# Altium schematic sheet PVCtrl.SchDoc(PVCtrl)
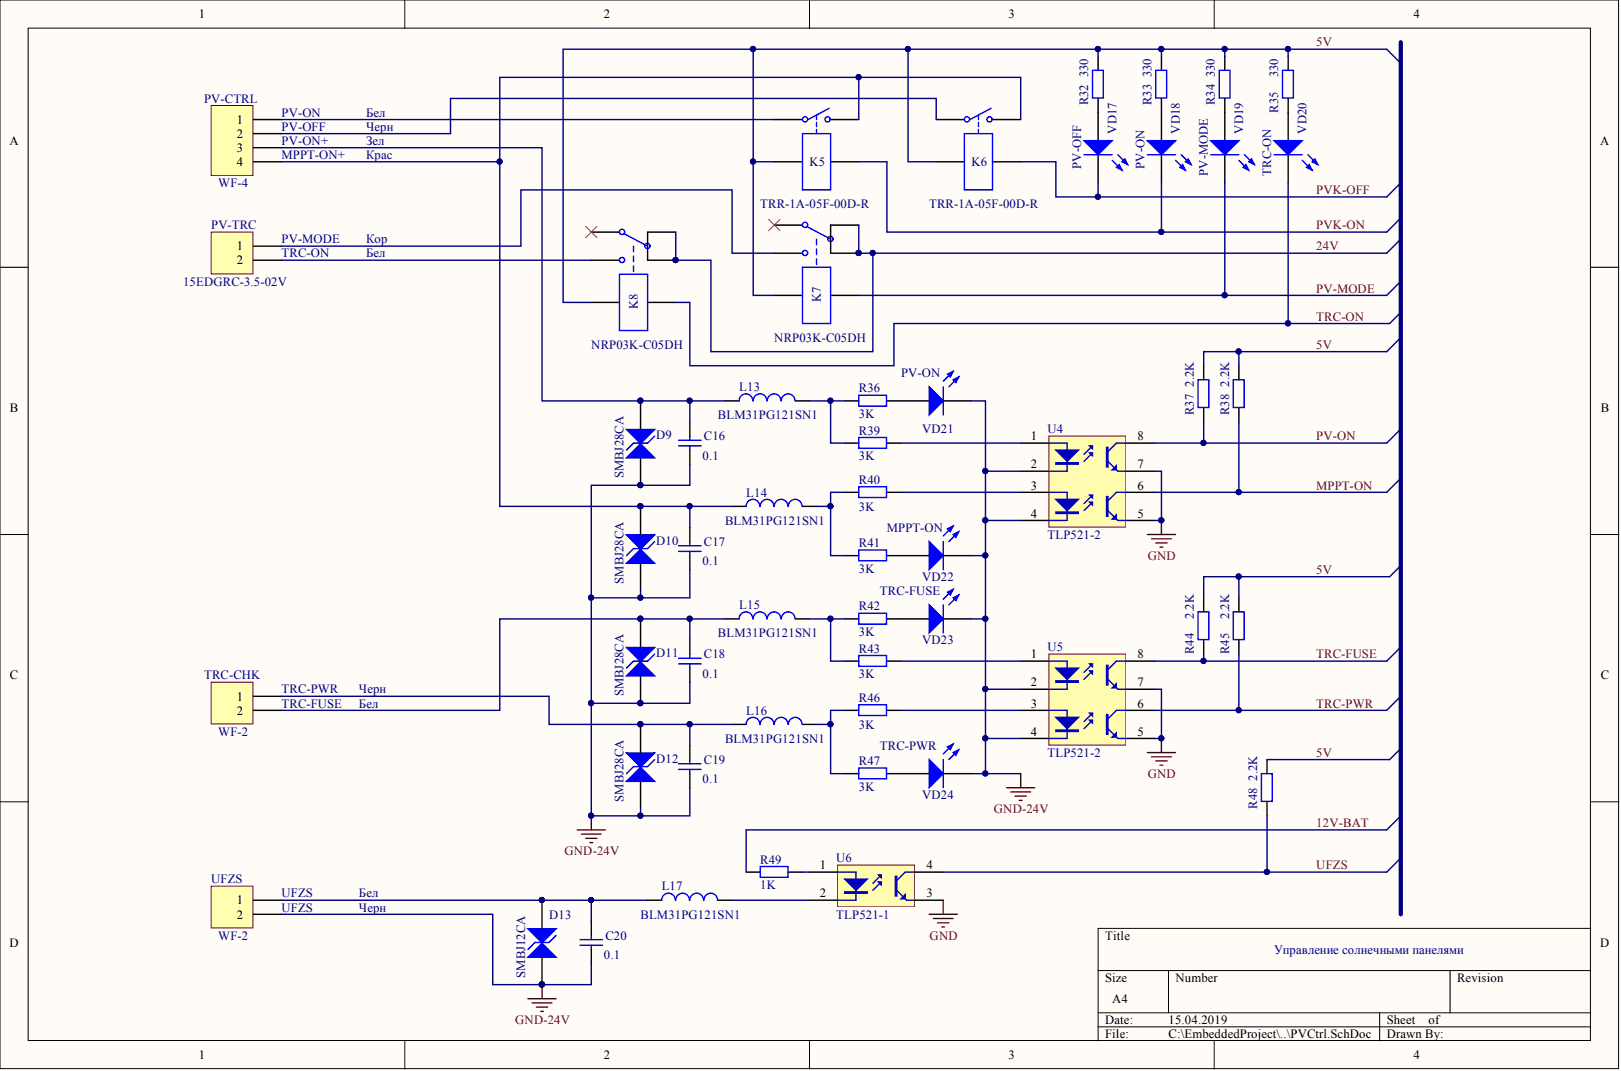
[diagram p0: PVCtrl.SchDoc]

## Parts
C16  value 0.1  [C1206]  (hidden comment: Cap)
C17  value 0.1  [C1206]  (hidden comment: Cap)
C18  value 0.1  [C1206]  (hidden comment: Cap)
C19  value 0.1  [C1206]  (hidden comment: Cap)
C20  value 0.1  [C1206]  (hidden comment: Cap)
D9  SMBJ28CA  [DO-214AA]
D10  SMBJ28CA  [DO-214AA]
D11  SMBJ28CA  [DO-214AA]
D12  SMBJ28CA  [DO-214AA]
D13  SMBJ12CA  [DO-214AA]
K5  value TRR-1A-05F-00D-R  [TRR-1A-05F-00D-R]  (hidden comment: Single-Pole Single-Throw Relay)
K6  value TRR-1A-05F-00D-R  [TRR-1A-05F-00D-R]  (hidden comment: Single-Pole Single-Throw Relay)
K7  value NRP03K-C05DH  [NRP03K-C05DH]  (hidden comment: Single-Pole Dual-Throw Relay)
K8  value NRP03K-C05DH  [NRP03K-C05DH]  (hidden comment: Single-Pole Dual-Throw Relay)
L13  value BLM31PG121SN1  [L1206]  (hidden comment: Ferrite Chip Beads)
L14  value BLM31PG121SN1  [L1206]  (hidden comment: Ferrite Chip Beads)
L15  value BLM31PG121SN1  [L1206]  (hidden comment: Ferrite Chip Beads)
L16  value BLM31PG121SN1  [L1206]  (hidden comment: Ferrite Chip Beads)
L17  value BLM31PG121SN1  [L1206]  (hidden comment: Ferrite Chip Beads)
PV-CTRL  value WF-4  [WF-4]  (hidden comment: Header, 4-Pin)
PV-TRC  value 15EDGRC-3.5-02V  [15EDGRC-3.5-02V]  (hidden comment: Header 2)
R32  value 330  [1206]  (hidden comment: Res2)
R33  value 330  [1206]  (hidden comment: Res2)
R34  value 330  [1206]  (hidden comment: Res2)
R35  value 330  [1206]  (hidden comment: Res2)
R36  value 3K  [1206]  (hidden comment: Res2)
R37  value 2.2K  [1206]  (hidden comment: Res2)
R38  value 2.2K  [1206]  (hidden comment: Res2)
R39  value 3K  [1206]  (hidden comment: Res2)
R40  value 3K  [1206]  (hidden comment: Res2)
R41  value 3K  [1206]  (hidden comment: Res2)
R42  value 3K  [1206]  (hidden comment: Res2)
R43  value 3K  [1206]  (hidden comment: Res2)
R44  value 2.2K  [1206]  (hidden comment: Res2)
R45  value 2.2K  [1206]  (hidden comment: Res2)
R46  value 3K  [1206]  (hidden comment: Res2)
R47  value 3K  [1206]  (hidden comment: Res2)
R48  value 2.2K  [1206]  (hidden comment: Res2)
R49  value 1K  [1206]  (hidden comment: Res2)
TRC-CHK  value WF-2  [WF-2]  (hidden comment: Header, 2-Pin)
U4  TLP521-2  [DIP-8 - OPTO]
U5  TLP521-2  [DIP-8 - OPTO]
U6  TLP521-1  [DIP-4 - OPTO]
UFZS  value WF-2  [WF-2]  (hidden comment: Header, 2-Pin)
VD17  PV-OFF  [LED1206 - RED]
VD18  PV-ON  [LED1206 - RED]
VD19  PV-MODE  [LED1206 - GREEN]
VD20  TRC-ON  [LED1206 - GREEN]
VD21  PV-ON  [LED1206 - BLUE]
VD22  MPPT-ON  [LED1206 - YELOW]
VD23  TRC-FUSE  [LED1206 - RED]
VD24  TRC-PWR  [LED1206 - GREEN]

## Nets
GND: U4.5 U4.7 U5.5 U5.7 U6.3
GND-24V: C16.2 C17.2 C18.2 C19.2 C20.2 D9.1 D10.1 D11.1 D12.1 D13.1 U4.2 U4.4 U5.2 U5.4 UFZS.2 VD21.2 VD22.2 VD23.2 VD24.2
5V: K5.3 K6.3 K7.5 K8.5 R32.1 R33.1 R34.1 R35.1 R37.1 R38.1 R44.1 R45.1 R48.1
12V-BAT: R49.2
24V: K7.1 K7.6 K8.1 K8.6
MPPT-ON: R38.2 U4.6
PV-MODE: K7.4 VD19.2
PV-ON: R37.2 U4.8
PVK-OFF: K6.5 VD17.2
PVK-ON: K5.5 VD18.2
TRC-FUSE: R44.2 U5.8
TRC-ON: K8.4 VD20.2
TRC-PWR: R45.2 U5.6
UFZS: R48.2 U6.4
NetC16_1: C16.1 D9.2 L13.1 PV-CTRL.3
NetC17_1: C17.1 D10.2 K5.7 K6.7 L14.1 PV-CTRL.4
NetC18_1: C18.1 D11.2 L15.1 TRC-CHK.2
NetC19_1: C19.1 D12.2 L16.1 TRC-CHK.1
NetC20_1: C20.1 D13.2 L17.1 UFZS.1
NetK5_1: K5.1 PV-CTRL.1
NetK6_1: K6.1 PV-CTRL.2
NetK7_3: K7.3 PV-TRC.1
NetK8_3: K8.3 PV-TRC.2
NetL13_2: L13.2 R36.1 R39.1
NetL14_2: L14.2 R40.1 R41.1
NetL15_2: L15.2 R42.1 R43.1
NetL16_2: L16.2 R46.1 R47.1
NetL17_2: L17.2 U6.2
NetR32_2: R32.2 VD17.1
NetR33_2: R33.2 VD18.1
NetR34_2: R34.2 VD19.1
NetR35_2: R35.2 VD20.1
NetR36_2: R36.2 VD21.1
NetR39_2: R39.2 U4.1
NetR40_2: R40.2 U4.3
NetR41_2: R41.2 VD22.1
NetR42_2: R42.2 VD23.1
NetR43_2: R43.2 U5.1
NetR46_2: R46.2 U5.3
NetR47_2: R47.2 VD24.1
NetR49_1: R49.1 U6.1
N00589:

Not connected: K7 2; K8 2

## Library data of the parts
C16-C20 = Cap: Capacitor; Component Kind: Standard; LatestRevisionDate: 17-Jul-2002; LatestRevisionNote: Re-released for DXP Platform.; Library Name: Miscellaneous Devices.IntLib; PackageReference: RAD-0.3; Published: 8-Jun-2000; Signal Integrity: Cap; Simulation: CAP; Publisher: Altium Limited
D9-D12 = SMBJ28CA: Voltage suppressor; Component Kind: Standard; Library Name: Schlib1.SchLib; Value: SMBJ28CA
D13 = SMBJ12CA: Voltage suppressor; Component Kind: Standard; Library Name: Schlib1.SchLib; Value: SMBJ12CA
K5 K6 = TRR-1A-05F-00D-R: Component Kind: Standard; Description: Single-Pole Single-Throw Relay; LatestRevisionDate: 17-Jul-2002; LatestRevisionNote: Re-released for DXP Platform.; Library Name: Schlib1.SchLib; PackageReference: MODULE4; Published: 24-Mar-1999; Simulation: SPSTRELAY; Publisher: Altium Limited
K7 K8 = NRP03K-C12DH: Component Kind: Standard; Description: Single-Pole Dual-Throw Relay; LatestRevisionDate: 17-Jul-2002; LatestRevisionNote: Re-released for DXP Platform.; Library Name: Schlib1.SchLib; PackageReference: MODULE5B; Published: 24-Mar-1999; Simulation: SPDTRELAY; Publisher: Altium Limited
L13-L17 = Inductor: Code_IEC: 1005; Code_JEITA: 0402; Component Kind: Standard; Description: Ferrite Chip Beads; LatestRevisionDate: 29-May-2009; LatestRevisionNote: IPC-7351 Footprint Added.; Library Name: Miscellaneous Devices.IntLib; PackageDescription: Chip Inductor; PackageReference: 0402-A; Published: 8-Jun-2000; Signal Integrity: Inductor; Simulation: INDUCTOR; Publisher: Altium Limited
PV-CTRL = Header 4: Component Kind: Standard; Description: Header, 4-Pin; LatestRevisionDate: 17-Jul-2002; LatestRevisionNote: Re-released for DXP Platform.; Library Name: Miscellaneous Connectors.IntLib; Signal Integrity: Connector; Publisher: Altium Limited
PV-TRC = Header 2: Header, 2-Pin; Component Kind: Standard; LatestRevisionDate: 17-Jul-2002; LatestRevisionNote: Re-released for DXP Platform.; Library Name: Miscellaneous Connectors.IntLib; Signal Integrity: Connector; Publisher: Altium Limited
R32-R49 = Res2: Resistor; Component Kind: Standard; LatestRevisionDate: 17-Jul-2002; LatestRevisionNote: Re-released for DXP Platform.; Library Name: Miscellaneous Devices.IntLib; PackageReference: AXIAL-0.4; Published: 8-Jun-2000; Signal Integrity: Res; Simulation: RESISTOR; Publisher: Altium Limited
TRC-CHK UFZS = Header 2: Component Kind: Standard; Description: Header, 2-Pin; LatestRevisionDate: 17-Jul-2002; LatestRevisionNote: Re-released for DXP Platform.; Library Name: Miscellaneous Connectors.IntLib; Signal Integrity: Connector; Publisher: Altium Limited
U4 U5 = TLP521-2: 8-Pin Phototransistor Optocoupler; Component Kind: Standard; DatasheetVersion: Nov-2003; LatestRevisionDate: 04-Mar-2005; LatestRevisionNote: Sch pins 3 & 4 Swapped.; Library Name: Schlib1.SchLib; PackageReference: DIP-4; Published: 24-Mar-1999; Value: TLP521-2; Publisher: Altium Limited
U6 = TLP521-1: 1 consist of a photo−transistor; Component Kind: Standard; DatasheetVersion: Nov-2003; LatestRevisionDate: 04-Mar-2005; LatestRevisionNote: Sch pins 3 & 4 Swapped.; Library Name: Schlib1.SchLib; PackageReference: DIP-4; Published: 24-Mar-1999; Value: TLP521-1; Publisher: Altium Limited
VD17 VD18 VD23 = LED0: SMD LED; value: RED; Component Kind: Standard; LatestRevisionDate: 08-Jul-2005; LatestRevisionNote: Mech Layer 1 removed.; Library Name: Miscellaneous Devices.IntLib; PackageReference: LED-0; Published: 8-Jun-2000; Simulation: LED0; Publisher: Altium Limited
VD19 VD20 VD24 = LED0: SMD LED; value: GREEN; Component Kind: Standard; LatestRevisionDate: 08-Jul-2005; LatestRevisionNote: Mech Layer 1 removed.; Library Name: Miscellaneous Devices.IntLib; PackageReference: LED-0; Published: 8-Jun-2000; Simulation: LED0; Publisher: Altium Limited
VD21 = LED0: SMD LED; value: BLUE; Component Kind: Standard; LatestRevisionDate: 08-Jul-2005; LatestRevisionNote: Mech Layer 1 removed.; Library Name: Miscellaneous Devices.IntLib; PackageReference: LED-0; Published: 8-Jun-2000; Simulation: LED0; Publisher: Altium Limited
VD22 = LED0: SMD LED; value: ORANGE; Component Kind: Standard; LatestRevisionDate: 08-Jul-2005; LatestRevisionNote: Mech Layer 1 removed.; Library Name: Miscellaneous Devices.IntLib; PackageReference: LED-0; Published: 8-Jun-2000; Simulation: LED0; Publisher: Altium Limited
